# Altium schematic sheet E16-02-001.SchDoc(E16-02-001)
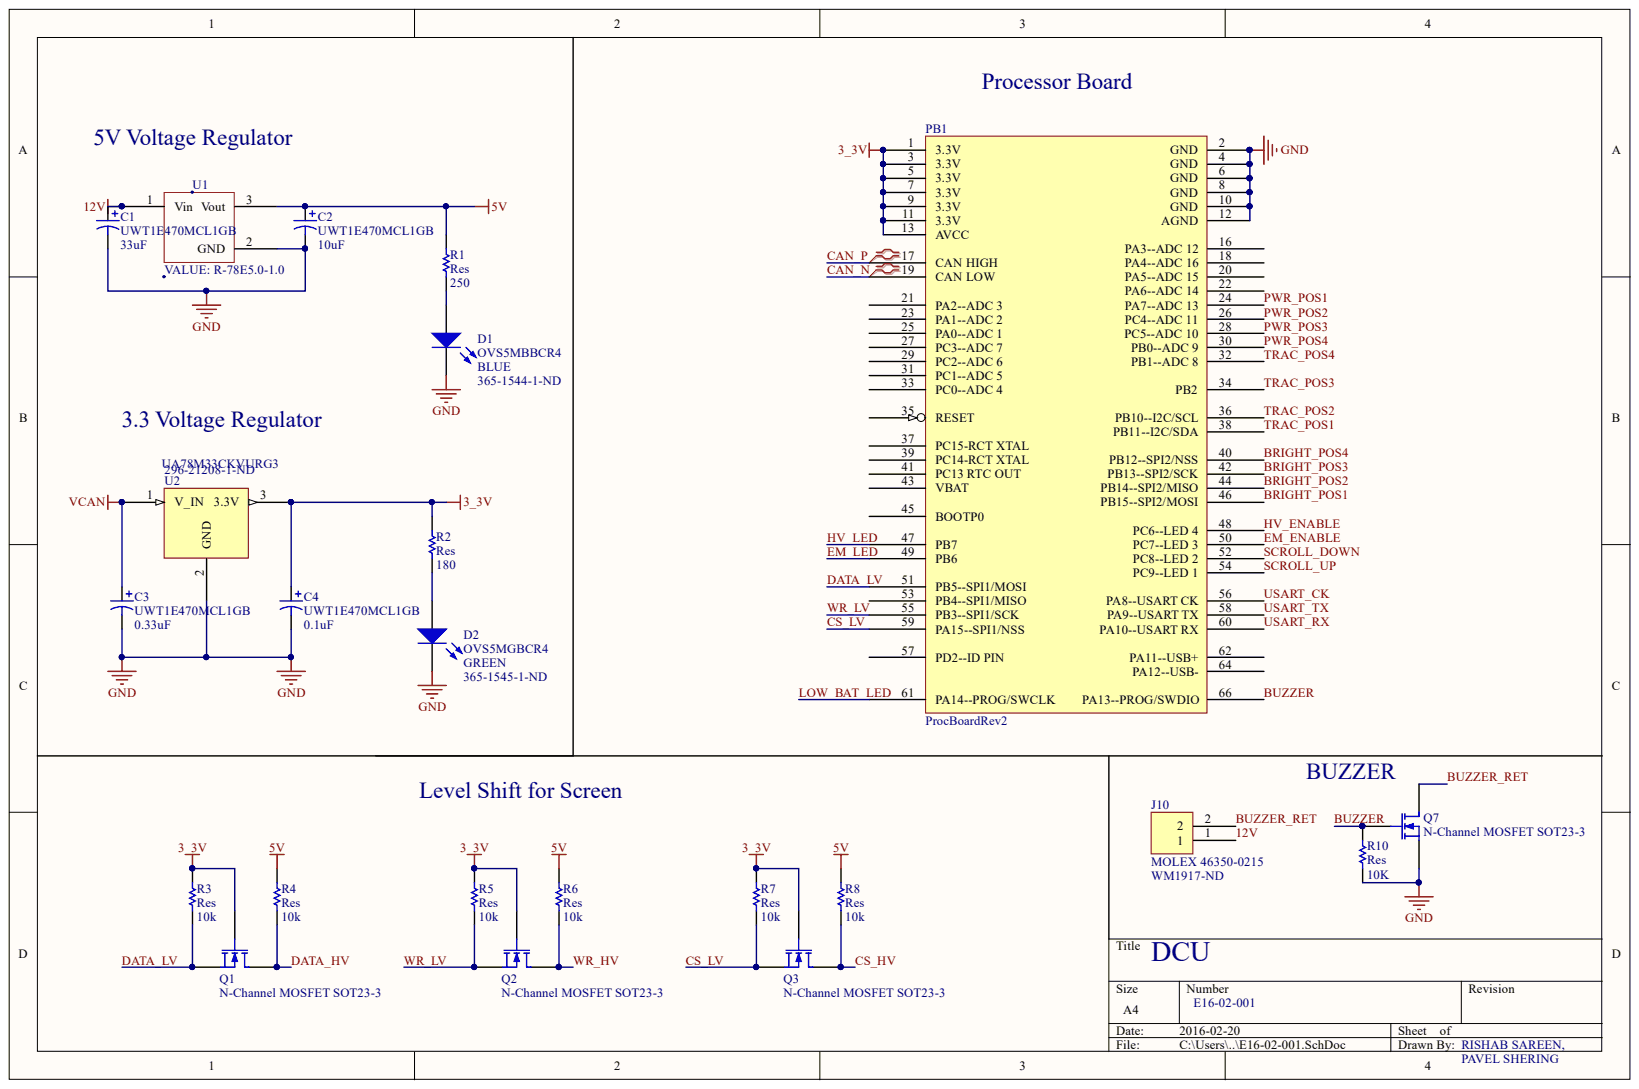
[diagram p0: E16-02-001.SchDoc]

## Parts
C1  UWT1E470MCL1GB  value 33uF  [UWT1E470MCL1GB]
C2  UWT1E470MCL1GB  value 10uF  [UWT1E470MCL1GB]
C3  UWT1E470MCL1GB  value 0.33uF  [UWT1E470MCL1GB]
C4  UWT1E470MCL1GB  value 0.1uF  [UWT1E470MCL1GB]
D1  OVS5MBBCR4  Colour: BLUE  Supplier Part Number 1: 365-1544-1-ND  [OVS5MBBCR4]
D2  OVS5MGBCR4  Colour: GREEN  Supplier Part Number 1: 365-1545-1-ND  [OVS5MGBCR4]
J10  MOLEX 46350-0215  Supplier Part Number 1: WM1917-ND  [MOLEX 43650-0215]
PB1  ProcBoardRev2  [PROC_BOARD]
Q1  N-Channel MOSFET SOT23-3  [SOT23-3]
Q2  N-Channel MOSFET SOT23-3  [SOT23-3]
Q3  N-Channel MOSFET SOT23-3  [SOT23-3]
Q7  N-Channel MOSFET SOT23-3  [SOT23-3]
R1  Res  value 250  [3216[1206]]
R2  Res  value 180  [3216[1206]]
R3  Res  value 10k  [3216[1206]]
R4  Res  value 10k  [3216[1206]]
R5  Res  value 10k  [3216[1206]]
R6  Res  value 10k  [3216[1206]]
R7  Res  value 10k  [3216[1206]]
R8  Res  value 10k  [3216[1206]]
R10  Res  value 10K  [3216[1206]]
U1  R-78E5.0-1.0  [R-78E5.0-1.0]
U2  UA78M33CKVURG3  Supplier Part Number 1: 296-21208-1-ND  [TO-252-3]

## Nets
3_3V: C4.1 PB1.1(3.3V) PB1.3(3.3V) PB1.5(3.3V) PB1.7(3.3V) PB1.9(3.3V) PB1.11(3.3V) PB1.13(AVCC) Q1.1 Q2.1 Q3.1 R2.2 R3.2 R5.2 R7.2 U2.3
5V: C2.1 R1.2 R4.2 R6.2 R8.2 U1.3
GND: C1.2 C2.2 C3.2 C4.2 D1.2 D2.2 PB1.2(GND) PB1.4(GND) PB1.6(GND) PB1.8(GND) PB1.10(GND) PB1.12(AGND) Q7.2 R10.1 U1.2 U2.2
VCAN: C3.1 U2.1
12V: C1.1 J10.1 U1.1
BRIGHT_POS1: PB1.46(PB15--SPI2/MOSI)
BRIGHT_POS2: PB1.44(PB14--SPI2/MISO)
BRIGHT_POS3: PB1.42(PB13--SPI2/SCK)
BRIGHT_POS4: PB1.40(PB12--SPI2/NSS)
BUZZER: PB1.66(PA13--PROG/SWDIO) Q7.1 R10.2
BUZZER_RET: J10.2 Q7.3
CAN_N: PB1.19(CAN_LOW)
CAN_P: PB1.17(CAN_HIGH)
CS_HV: Q3.3 R8.1
CS_LV: PB1.59(PA15--SPI1/NSS) Q3.2 R7.1
DATA_HV: Q1.3 R4.1
DATA_LV: PB1.51(PB5--SPI1/MOSI) Q1.2 R3.1
EM_ENABLE: PB1.50(PC7--LED_3)
EM_LED: PB1.49(PB6)
HV_ENABLE: PB1.48(PC6--LED_4)
HV_LED: PB1.47(PB7)
LOW_BAT_LED: PB1.61(PA14--PROG/SWCLK)
PWR_POS1: PB1.24(PA7--ADC_13)
PWR_POS2: PB1.26(PC4--ADC_11)
PWR_POS3: PB1.28(PC5--ADC_10)
PWR_POS4: PB1.30(PB0--ADC_9)
SCROLL_DOWN: PB1.52(PC8--LED_2)
SCROLL_UP: PB1.54(PC9--LED_1)
TRAC_POS1: PB1.38(PB11--I2C/SDA)
TRAC_POS2: PB1.36(PB10--I2C/SCL)
TRAC_POS3: PB1.34(PB2)
TRAC_POS4: PB1.32(PB1--ADC_8)
USART_CK: PB1.56(PA8--USART_CK)
USART_RX: PB1.60(PA10--USART_RX)
USART_TX: PB1.58(PA9--USART_TX)
WR_HV: Q2.3 R6.1
WR_LV: PB1.55(PB3--SPI1/SCK) Q2.2 R5.1
NetD1_1: D1.1 R1.1
NetD2_1: D2.1 R2.1

Not connected: PB1 16(PA3--ADC_12) 18(PA4--ADC_16) 20(PA5--ADC_15) 21(PA2--ADC_3) 22(PA6--ADC_14) 23(PA1--ADC_2) 25(PA0--ADC_1) 27(PC3--ADC_7) 29(PC2--ADC_6) 31(PC1--ADC_5) 33(PC0--ADC_4) 35(RESET) 37(PC15-RCT_XTAL) 39(PC14-RCT_XTAL) 41(PC13_RTC_OUT) 43(VBAT) 45(BOOTP0) 53(PB4--SPI1/MISO) 57(PD2--ID_PIN) 62(PA11--USB+) 64(PA12--USB-)

## Library data of the parts
C1-C4 = UWT1E470MCL1GB: CAP ALUM 47UF 25V 20% SMD; Component Kind: Standard; LatestRevisionDate: 17-Jul-2002; LatestRevisionNote: Re-released for DXP Platform.; Library Name: Capacitors.IntLib; PackageDescription: Polarized Capacitor; 2 Leads; PackageReference: POLAR0.8; Published: 8-Jun-2000; Publisher: Altium Limited
D1 = OVS5MBBCR4: Typical BLUE LED; Component Kind: Standard; LatestRevisionDate: 17-Jul-2002; LatestRevisionNote: Re-released for DXP Platform.; Library Name: LEDs.IntLib; PackageDocument: Feb-2002; PackageReference: 3.2X1.6X1.1; Published: 8-Jun-2000; Supplier 1: Digi-Key; Publisher: Altium Limited
D2 = OVS5MGBCR4: Typical GREEN LED; Component Kind: Standard; LatestRevisionDate: 17-Jul-2002; LatestRevisionNote: Re-released for DXP Platform.; Library Name: LEDs.IntLib; PackageDocument: Feb-2002; PackageReference: 3.2X1.6X1.1; Published: 8-Jun-2000; Supplier 1: Digi-Key; Publisher: Altium Limited
J10 = MOLEX 46350-0215: Molex 2 Pin Male Header, Vertical, Through Hole; Component Kind: Standard; Library Name: Connectors and Headers.IntLib; Supplier 1: Digi-Key
PB1 = ProcBoardRev2: Processor board that carries the STM32F072RB; Component Kind: Standard; Library Name: Microcontrollers.IntLib
Q1-Q3 Q7 = MOSFET-N SOT23-3: Component Kind: Standard; Description: N-Channel MOSFET SOT23-3; Library Name: Transistors.IntLib
R1-R8 R10 = Res: Surface Mount Resistor; Component Kind: Standard; LatestRevisionDate: 17-Jul-2002; LatestRevisionNote: Re-released for DXP Platform.; Library Name: Resistors.IntLib; PackageReference: AXIAL-0.5; Published: 8-Jun-2000; Publisher: Altium Limited
U1 = R-78E5.0-1.0: Recom Power 28V to 5V; Component Kind: Standard; MFG: Recom Power; MFG_PN: R-78E5.0-1.0; Supplier 1: Digi-Key; Supplier Part Number 1: 945-2201-ND; VALUE: R-78E5.0-1.0; Library Name: Voltage Regulation.IntLib
U2 = UA78M33CKVURG3: 3.3V Regulator; Component Kind: Standard; Supplier 1: Digi-Key; Library Name: Voltage Regulation.IntLib
